# Altium schematic sheet Multivibrator.SchDoc
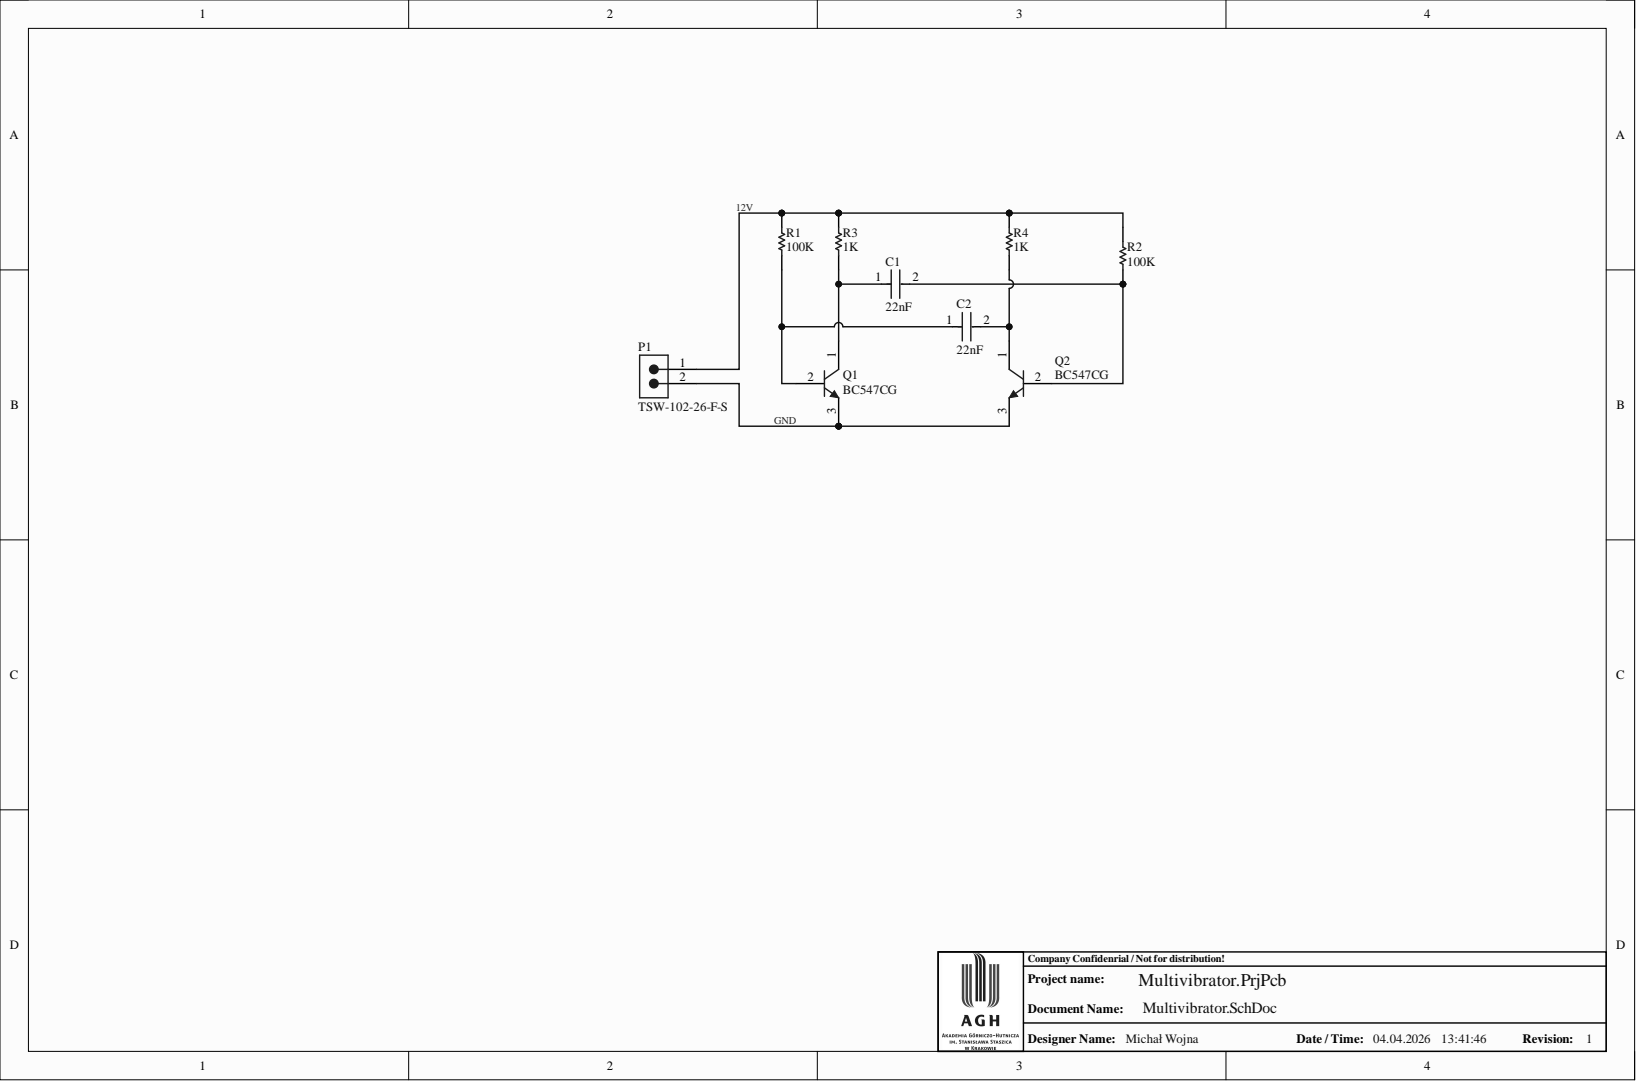
[diagram p0: Multivibrator.SchDoc]

## Parts
C1  22nF  [FP-C0603C-CF-MFG]
C2  22nF  [FP-C0603C-CF-MFG]
P1  TSW-102-26-F-S  [SMTC-TSW-102-26-XX-S]
Q1  BC547CG  [ONSC-TO-92-3-29-11]
Q2  BC547CG  [ONSC-TO-92-3-29-11]
R1  100K  [RESC2013X70X40NL20T20]
R2  100K  [RESC2013X70X40NL20T20]
R3  1K  [RESC2013X70X40LL20T20]
R4  1K  [RESC2013X70X40LL20T20]

## Nets
12V: P1.1 R1.2 R2.2 R3.2 R4.2
GND: P1.2 Q1.3 Q2.3
NetC1_1: C1.1 Q1.1 R3.1
NetC1_2: C1.2 Q2.2 R2.1
NetC2_1: C2.1 Q1.2 R1.1
NetC2_2: C2.2 Q2.1 R4.1

## Library data of the parts
C1 C2 = CMP-2006-03745-2: CAP CER 0.022UF 16V 5% X7R 0603; Manufacturer: KEMET; Manufacturer Part Number: C0603C223J4RACTU; Automotive Grade: Grade 1; Capacitance: 22,000 pF; Material: Ceramic; Temperature Coefficient: 30ppm/°C; Tolerance: 0.05; Value: 22,000 pF; Automotive: Yes; Category: Cap; Component Kind: Standard; ComponentLink2Description: Datasheet; ComponentLink2URL: https://api.kemet.com/component-edge/download/datasheet/C0603C100J5GACAUTO.pdf; Device Class L1: Passive Components; Device Class L2: Capacitors; Device Class L3: Ceramic Capacitors; Digikey Description: CAP CER 0.022UF 16V 5% X7R 0603; Height: 0.87mm; Lead Free: Yes; Library Name: Altium Content Vault; Mouser Description: Multilayer Ceramic Capacitors MLCC - SMD/SMT 16volts 22000pF X7R 5%; Octopart: https://octopart.com/c0603c223j4ractu-kemet-12542861; Package: C0603C-CF; RoHS: TRUE; Temperature: -55°C to +125°C; Temperature Characteristic: X7R; Temperature Range High: +125°C; Temperature Range Low: -55°C; Voltage Rating: 16 V
P1 = CMP-1024-00329-1: 0.025" SQ Post Header, Through-hole, Vertical, -55 to 125 degC, 2.54 mm Pitch, 2-Pin, Male, RoHS; Manufacturer: Samtec; Pitch: 2.54 mm; Component Kind: Standard; ComponentLink1Description: Manufacturer URL; ComponentLink1URL: http://www.samtec.com; ComponentLink2Description: Datasheet; ComponentLink2URL: http://www.samtec.com/documents/webfiles/pdf/TSW_TH.PDF; ComponentLink3Description: Package Specification; ComponentLink3URL: http://www.samtec.com/documents/webfiles/cpdf/TSW-XXX-XX-XXX-X-XX-XXX-MKT.pdf; DatasheetVersion: F-214; Library Name: Altium Content Vault; Mounting Technology: Through-hole; Orientation: Vertical; PackageDescription: .025[0.64] SQ. Post Terminal Strip Assembly; PackageReference: TSW-102-26-XX-S; PackageVersion: DM, 8/1988; PartNumber: TSW-102-26-F-S; RoHS: True; Temperature Range: -55 to 125 degC
Q1 Q2 = CMP-1048-01464-1: Amplifier Transistor, NPN Silicon, 3-Pin TO-92, Pb-Free, Bulk Box; Manufacturer: On Semiconductor; fT Min (MHz): 150; IC Continuous (A): 0.1; Component Kind: Standard; ComponentLink1Description: Manufacturer URL; ComponentLink1URL: http://www.onsemi.com/; ComponentLink2Description: Datasheet; ComponentLink2URL: http://www.onsemi.com/pub_link/Collateral/BC546-D.PDF; ComponentLink3Description: Package Specification; ComponentLink3URL: http://www.onsemi.cn/pub_link/Collateral/2N6426-D.PDF; DatasheetVersion: Rev. 7; Library Name: Altium Content Vault; Mounting Technology: Through Hole; PackageDescription: 3-Pin Transistor Outline, Body 4.825 x 3.685 mm, Pitch 1.27 mm; PackageReference: TO-92-3-29-11_AM_SL; PackageVersion: Rev. AM; Packing: Bulk Box; PartNumber: BC547CG; Polarity: NPN; RoHS: TRUE
R1 R2 = CMP-2001-00338-1: Manufacturer: Panasonic; Part Number: ERJ-6GEYJ104V; Component Kind: Standard; Library Name: Altium Content Vault
R3 R4 = CMP-2001-00477-1: Manufacturer: Panasonic; Part Number: ERJ-P06J102V; Component Kind: Standard; Library Name: Altium Content Vault
